# Altium schematic sheet 12008 - Servo CAN Node.SchDoc("12008 - Servo CAN Node")
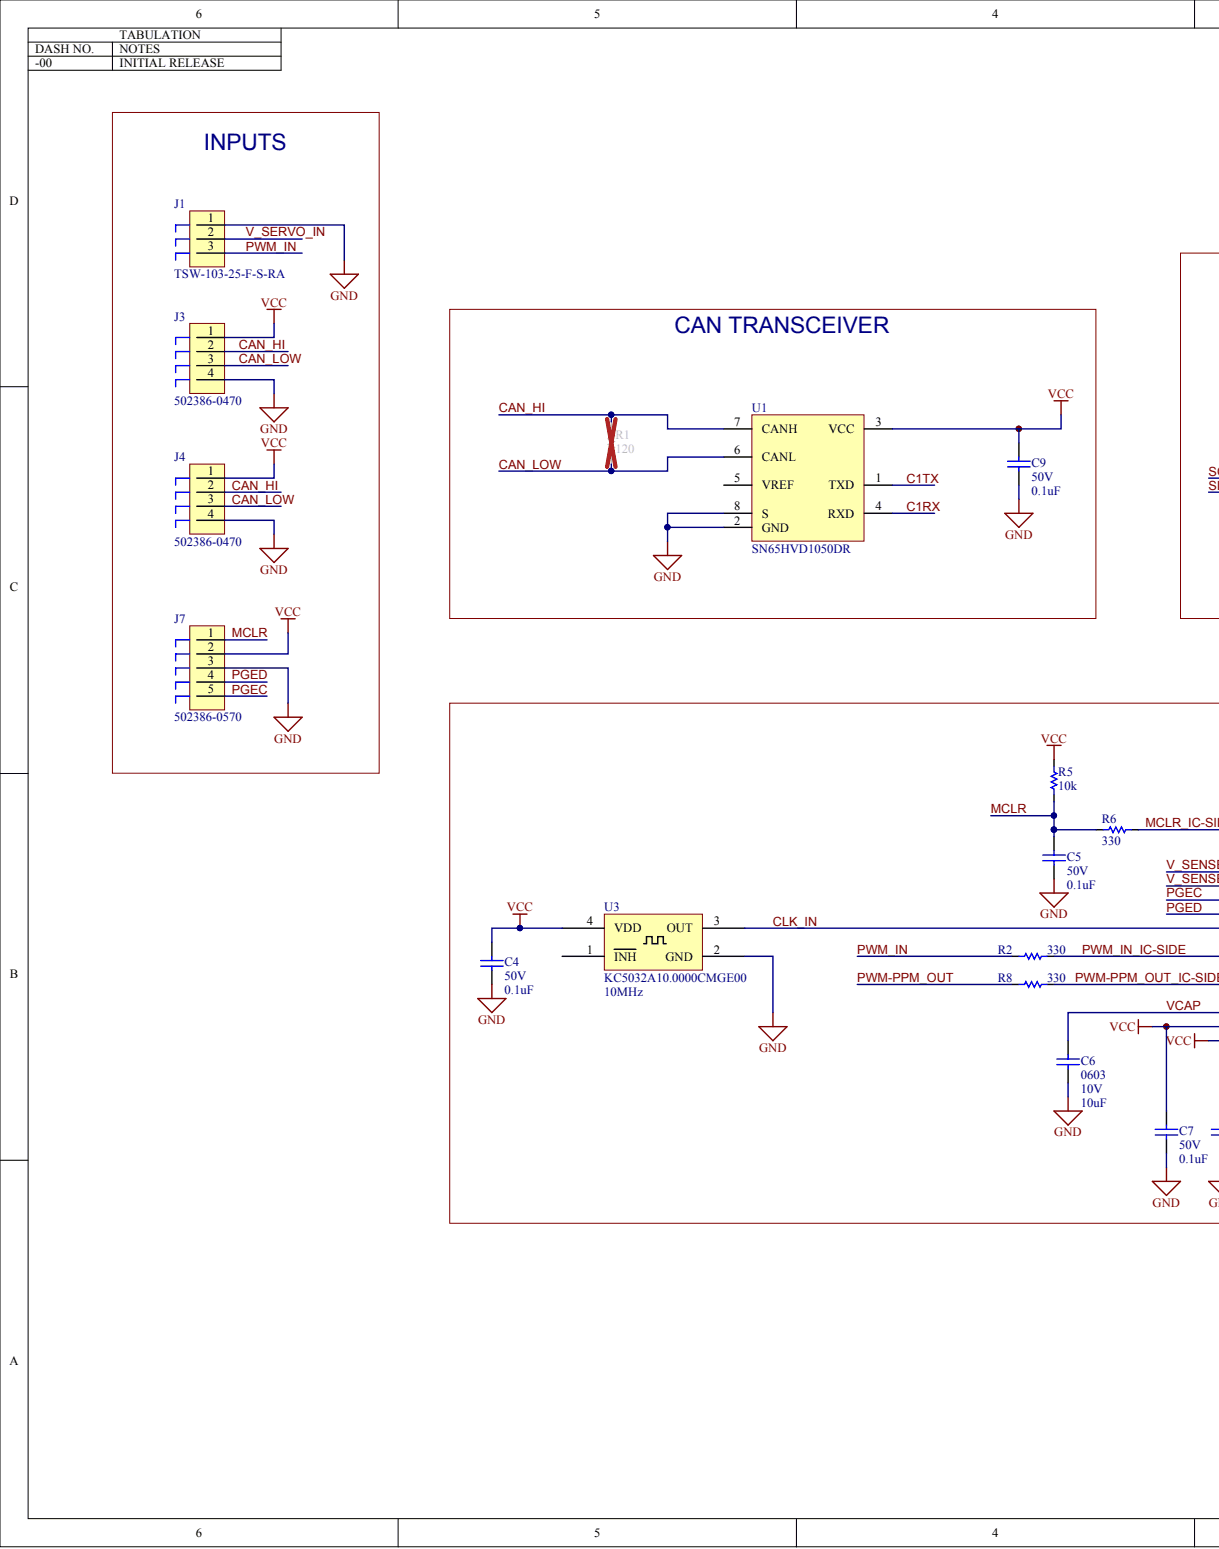
[diagram p0: 12008 - Servo CAN Node.SchDoc, left]
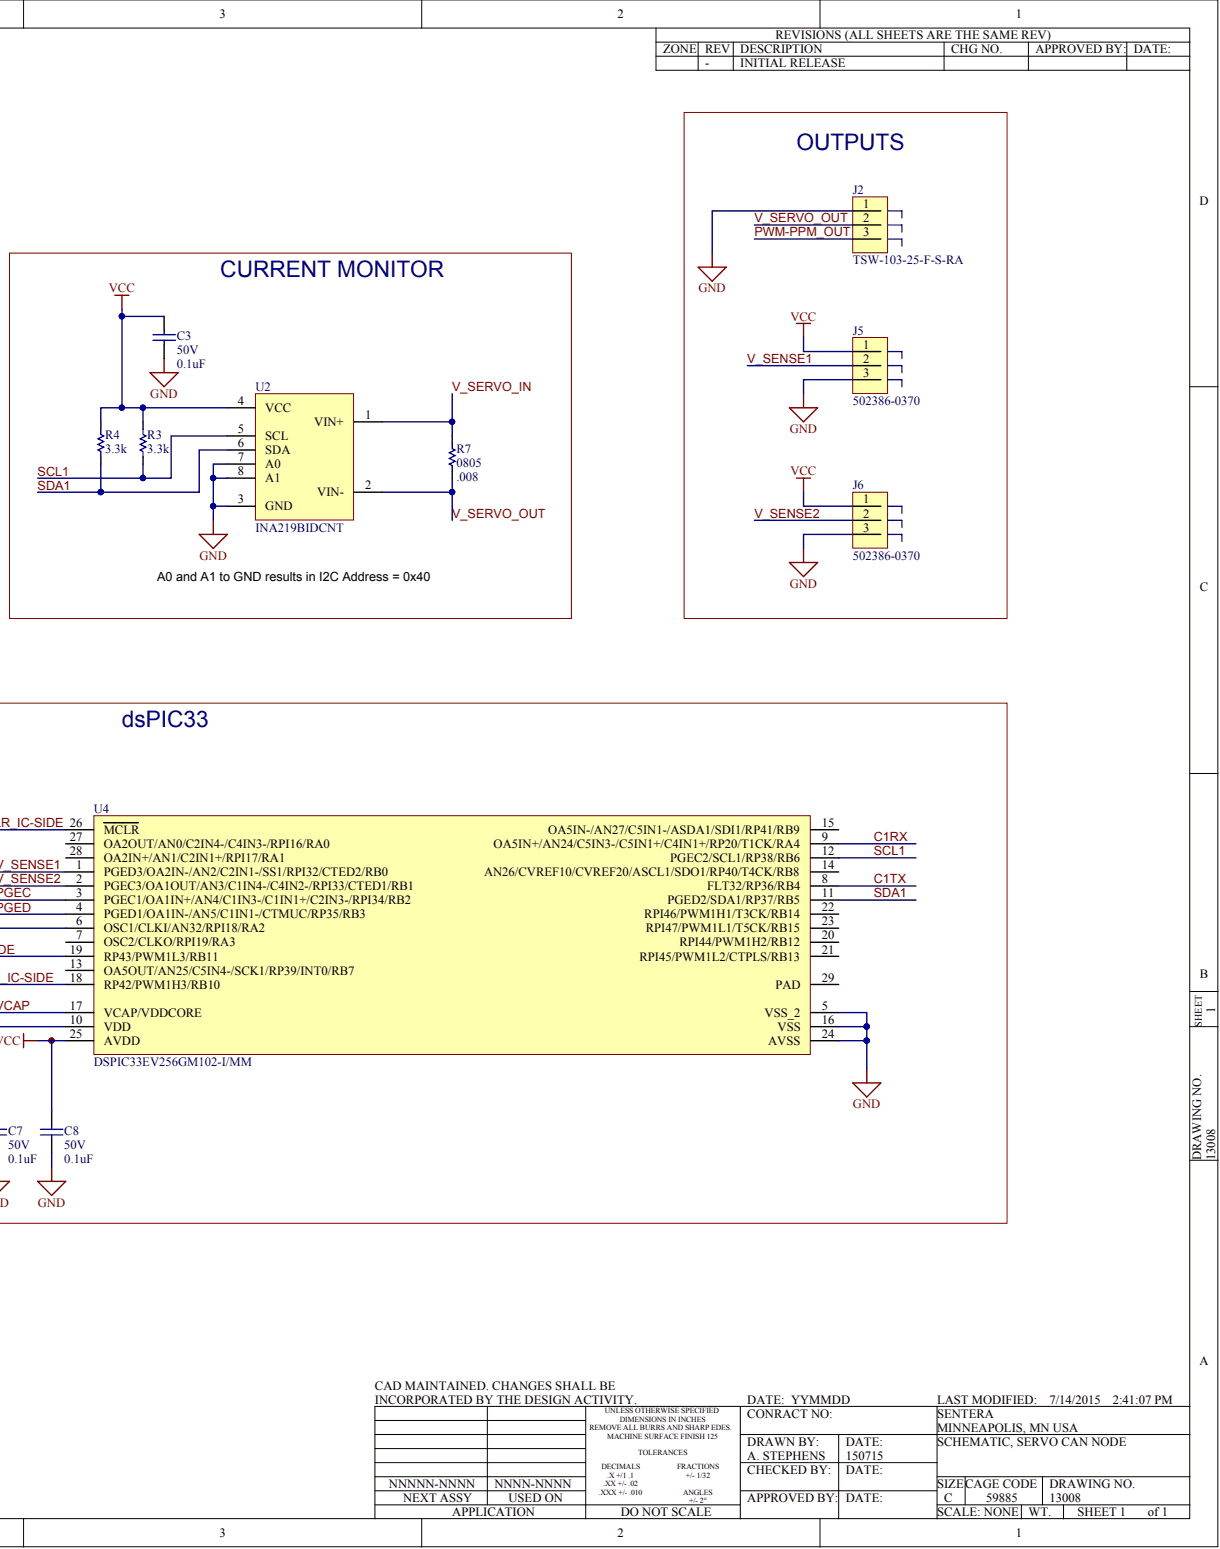
[diagram p1: 12008 - Servo CAN Node.SchDoc, right]

## Parts
C3  value 0.1uF  Voltage - Rated: 50V  [0402 CAPC1005N - MD]  (hidden comment: C1005X7R1H104K)
C4  value 0.1uF  Voltage - Rated: 50V  [0402 CAPC1005N - MD]  (hidden comment: C1005X7R1H104K)
C5  value 0.1uF  Voltage - Rated: 50V  [0402 CAPC1005N - MD]  (hidden comment: C1005X7R1H104K)
C6  value 10uF  Package: 0603  Voltage: 10V  [0603 CAPC1608N - MD]  (hidden comment: C1608X5R1A106M)
C7  value 0.1uF  Voltage - Rated: 50V  [0402 CAPC1005N - MD]  (hidden comment: C1005X7R1H104K)
C8  value 0.1uF  Voltage - Rated: 50V  [0402 CAPC1005N - MD]  (hidden comment: C1005X7R1H104K)
C9  value 0.1uF  Voltage - Rated: 50V  [0402 CAPC1005N - MD]  (hidden comment: C1005X7R1H104K)
J1  TSW-103-25-F-S-RA  [TSW-103-25-F-S-RA]
J2  TSW-103-25-F-S-RA  [TSW-103-25-F-S-RA]
J3  502386-0470  [502386-0470]
J4  502386-0470  [502386-0470]
J5  502386-0370  [502386-0370]
J6  502386-0370  [502386-0370]
J7  502386-0570  [502386-0570]
R1  value 120  [0402 RESC1005N MD]  (hidden comment: ERJ-2RKF1200X)
R2  value 330  [0402 RESC1005N MD]  (hidden comment: ERJ-2RKF3300X)
R3  value 3.3k  [0402 RESC1005N MD]  (hidden comment: ERJ-2RKF3301X)
R4  value 3.3k  [0402 RESC1005N MD]  (hidden comment: ERJ-2RKF3301X)
R5  value 10k  [0402 RESC1005N MD]  (hidden comment: ERJ-2RKF1002X)
R6  value 330  [0402 RESC1005N MD]  (hidden comment: ERJ-2RKF3300X)
R7  value .008  Package / Case: 0805  [0805 RESC2012N - MD]  (hidden comment: PMR10EZPFU8L00)
R8  value 330  [0402 RESC1005N MD]  (hidden comment: ERJ-2RKF3300X)
U1  SN65HVD1050DR  [SOIC127P600X175-8N]
U2  INA219BIDCNT  [SOT23-8 3x3mm]
U3  KC5032A10.0000CMGE00  Frequency: 10MHz  [DFN-4 5x3.2mm]
U4  DSPIC33EV256GM102-I/MM  [QFN-28 6x6mm]

## Nets
GND: C3.2 C4.2 C5.2 C6.2 C7.2 C8.2 C9.2 J1.1 J2.1 J3.4 J4.4 J5.3 J6.3 J7.3 U1.2(GND) U1.8(S) U2.3(GND) U2.7(A0) U2.8(A1) U3.2(GND) U4.5(VSS_2) U4.16(VSS) U4.24(AVSS)
VCC: C3.1 C4.1 C7.1 C8.1 C9.1 J3.1 J4.1 J5.1 J6.1 J7.2 R3.1 R4.1 R5.1 U1.3(VCC) U2.4(VCC) U3.4(VDD) U4.10(VDD) U4.25(AVDD)
C1RX: U1.4(RXD) U4.9(OA5IN+/AN24/C5IN3-/C5IN1+/C4IN1+/RP20/T1CK/RA4)
C1TX: U1.1(TXD) U4.8(FLT32/RP36/RB4)
CAN_HI: J3.2 J4.2 R1.1 U1.7(CANH)
CAN_LOW: J3.3 J4.3 R1.2 U1.6(CANL)
CLK_IN: U3.3(OUT) U4.6(OSC1/CLKI/AN32/RPI18/RA2)
MCLR: C5.1 J7.1 R5.2 R6.1
MCLR_IC-SIDE: R6.2 U4.26(MCLR)
PGEC: J7.5 U4.3(PGEC1/OA1IN+/AN4/C1IN3-/C1IN1+/C2IN3-/RPI34/RB2)
PGED: J7.4 U4.4(PGED1/OA1IN-/AN5/C1IN1-/CTMUC/RP35/RB3)
PWM-PPM_OUT: J2.3 R8.1
PWM-PPM_OUT_IC-SIDE: R8.2 U4.18(RP42/PWM1H3/RB10)
PWM_IN: J1.3 R2.1
PWM_IN_IC-SIDE: R2.2 U4.19(RP43/PWM1L3/RB11)
SCL1: R3.2 U2.5(SCL) U4.12(PGEC2/SCL1/RP38/RB6)
SDA1: R4.2 U2.6(SDA) U4.11(PGED2/SDA1/RP37/RB5)
V_SENSE1: J5.2 U4.1(PGED3/OA2IN-/AN2/C2IN1-/SS1/RPI32/CTED2/RB0)
V_SENSE2: J6.2 U4.2(PGEC3/OA1OUT/AN3/C1IN4-/C4IN2-/RPI33/CTED1/RB1)
V_SERVO_IN: J1.2 R7.1 U2.1(VIN+)
V_SERVO_OUT: J2.2 R7.2 U2.2(VIN-)
VCAP: C6.1 U4.17(VCAP/VDDCORE)

Not connected: U1 5(VREF); U3 1(INH); U4 7(OSC2/CLKO/RPI19/RA3) 13(OA5OUT/AN25/C5IN4-/SCK1/RP39/INT0/RB7) 14(AN26/CVREF10/CVREF20/ASCL1/SDO1/RP40/T4CK/RB8) 15(OA5IN-/AN27/C5IN1-/ASDA1/SDI1/RP41/RB9) 20(RPI44/PWM1H2/RB12) 21(RPI45/PWM1L2/CTPLS/RB13) 22(RPI46/PWM1H1/T3CK/RB14) 23(RPI47/PWM1L1/T5CK/RB15) 27(OA2OUT/AN0/C2IN4-/C4IN3-/RPI16/RA0) 28(OA2IN+/AN1/C2IN1+/RPI17/RA1) 29(PAD)

## Library data of the parts
C3-C5 C7-C9 = C1005X7R1H104K: CAP CER 0.1UF 50V 10% X7R 0402; Manufacturer: TDK Corporation; Approved: 00-111227; Capacitance: 0.1µF; Applications: General Purpose; Category: Capacitors; Component Kind: Standard; ComponentLink1Description: http://www.tdk.co.jp/tefe02/e412_c.pdf; ComponentLink1URL: http://www.tdk.co.jp/tefe02/e412_c.pdf; Features: -; Height - Seated (Max): -; Lead Spacing: -; Lead Style: -; Manufacturer Part Number: C1005X7R1H104K; Modified: 00-111116; Mounting Type: Surface Mount, MLCC; Operating Temperature: -55°C ~ 125°C; Package / Case: 0402 (1005 Metric); Packaging: Cut Tape (CT); Pricing 1: 1=0.3, 10=0.2, 100=0.08, 250=0.05752, 500=0.041, 1000=0.03 (USD); Ratings: -; RoHS: RoHS Compliant; Signal Integrity: Cap; Simulation: CAP; Size / Dimension: 0.039" L x 0.020" W (1.00mm x 0.50mm); Stock 1: 27550; Supplier 1: Digi-Key; Supplier Part Number 1: 445-5932-1-ND; Temperature Coefficient: X7R; Thickness (Max): 0.022" (0.55mm); Tolerance: ±10%; Approved By: D. Schoppe; Library Name: SUAS - Capacitors - Ceramic.SchLib; Modified By: D. Schoppe
C6 = C1608X5R1A106M: CAP CER 10UF 10V X5R 20% 0603; Manufacturer: TDK; Approved: 00-111202; Capacitance: 10µF; Type: Ceramic; Applications: General Purpose; Category: Capacitors; Component Kind: Standard; ComponentLink1Description: http://www.tdk.co.jp/tefe02/e412_c.pdf; ComponentLink1URL: http://www.tdk.co.jp/tefe02/e412_c.pdf; Features: -; Height: -; Lead Spacing: -; Lead Style: -; Manufacturer Part Number: C1608X5R1A106M; Modified: 00-111010; Mounting Type: Surface Mount, MLCC; Operating Temperature: -55°C ~ 85°C; Package / Case: 0603 (1608 Metric); Packaging: Cut Tape (CT); Pricing 1: 1=0.58, 10=0.457, 100=0.275, 250=0.20624, 500=0.176, 1000=0.1375 (USD); Ratings: -; RoHS: RoHS Compliant; Signal Integrity: Cap; Simulation: CAP; Size / Dimension: 0.063" L x 0.031" W (1.60mm x 0.80mm); Stock 1: 16926; Supplier 1: Digi-Key; Supplier Part Number 1: 445-6853-1-ND; Temperature Coefficient: X5R; Thickness: 0.035" (0.90mm); Tolerance: 20%; Voltage - Rated: 10V; Approved By: A. Stephens; Library Name: SUAS - Capacitors - Ceramic.SchLib; Modified By: A. Stephens
J1 J2 = TSW-103-25-F-S-RA: CONN HEADER 3POS .100" R/A AU; Manufacturer: Samtec Inc; Connector Type: Header, Unshrouded; Number of Positions: 3; Number of Positions Loaded: All; Pitch: 0.100" (2.54mm); Category: Connectors, Interconnects; Color: Black; Component Kind: Standard; ComponentLink1Description: http://www.samtec.com/ftppub/cpdf/TSW-XXX-XX-XXX-X-XX-XXX-MKT.pdf; ComponentLink1URL: http://www.samtec.com/ftppub/cpdf/TSW-XXX-XX-XXX-X-XX-XXX-MKT.pdf; Contact Finish: Gold; Contact Finish Thickness: 3µin (0.08µm); Contact Mating Length: 0.320" (8.13mm); Contact Type: Male Pin; Fastening Type: -; Features: -; Manufacturer Part Number: TSW-103-25-F-S-RA; Modified: 00-150714; Mounting Type: Through Hole, Right Angle; Number of Rows: 1; Packaging: Tube; Pricing 1: 1=0.46 (USD); RoHS: RoHS Compliant; Row Spacing: -; Stock 1: 0; Supplier 1: Digi-Key; Supplier Part Number 1: TSW-103-25-F-SRA-ND; Termination: Solder; Library Name: SUAS - Connectors.SchLib; Modified By: A. Stephens
J3 J4 = 502386-0470: CLIK-Mate CONN RCPT 4POS 1.25MM R/A SMD; Manufacturer: Molex Inc; Manufacturer Part Number: 5023860470; Connector Type: Receptacle; Number of Positions: 4; Number of Positions Loaded: All; Pitch: 0.049" (1.25mm); Category: Connectors, Interconnects; Color: Natural; Component Kind: Standard; ComponentLink1Description: http://www.molex.com/pdm_docs/sd/5023860470_sd.pdf; ComponentLink1URL: http://www.molex.com/pdm_docs/sd/5023860470_sd.pdf; Contact Finish: Tin; Contact Finish Thickness: 39µin (1.00µm); Contact Type: Center Strip Contact; Fastening Type: Latch Holder; Features: Solder Retention; Modified: 00-150714; Mounting Type: Surface Mount, Right Angle; Number of Rows: 1; Packaging: Cut Tape (CT); Pricing 1: 1=1.22, 10=1.07, 25=1.0068, 50=0.9646, 100=0.9227, 250=0.83888, 500=0.75498 (USD); RoHS: RoHS Compliant; Row Spacing: -; Stock 1: 3060; Supplier 1: Digi-Key; Supplier Part Number 1: WM4948CT-ND; Termination: Solder; Library Name: SUAS - Connectors.SchLib; Modified By: A. Stephens
J5 J6 = 502386-0370: CONN RCPT 3POS 1.25MM R/A SMD; Manufacturer: Molex Inc; Manufacturer Part Number: 5023860370; Connector Type: Receptacle; Number of Positions: 3; Number of Positions Loaded: All; Pitch: 0.049" (1.25mm); Category: Connectors, Interconnects; Color: Natural; Component Kind: Standard; ComponentLink1Description: http://www.molex.com/pdm_docs/sd/5023860370_sd.pdf; ComponentLink1URL: http://www.molex.com/pdm_docs/sd/5023860370_sd.pdf; Contact Finish: Tin; Contact Finish Thickness: 39µin (1.00µm); Contact Type: Center Strip Contact; Fastening Type: Latch Holder; Features: Solder Retention; Modified: 00-150714; Mounting Type: Surface Mount, Right Angle; Number of Rows: 1; Packaging: Cut Tape (CT); Pricing 1: 1=1.11, 10=0.977, 25=0.9196, 50=0.8814, 100=0.8431, 250=0.76648, 500=0.68984 (USD); RoHS: RoHS Compliant; Row Spacing: -; Stock 1: 1588; Supplier 1: Digi-Key; Supplier Part Number 1: WM4947CT-ND; Termination: Solder; Library Name: SUAS - Connectors.SchLib; Modified By: A. Stephens
J7 = 502386-0570: CLIK-Mate CONN RCPT 5POS 1.25MM R/A SMD; Manufacturer: Molex Inc; Manufacturer Part Number: 5023860570; Connector Type: Receptacle; Number of Positions: 5; Number of Positions Loaded: All; Pitch: 0.049" (1.25mm); Category: Connectors, Interconnects; Color: Natural; Component Kind: Standard; ComponentLink1Description: http://www.molex.com/pdm_docs/sd/5023860570_sd.pdf; ComponentLink1URL: http://www.molex.com/pdm_docs/sd/5023860570_sd.pdf; Contact Finish: Tin; Contact Finish Thickness: 39µin (1.00µm); Contact Type: Center Strip Contact; Fastening Type: Latch Holder; Features: Solder Retention; Modified: 00-150714; Mounting Type: Surface Mount, Right Angle; Number of Rows: 1; Packaging: Cut Tape (CT); Pricing 1: 1=1.34, 10=1.178, 25=1.1088, 50=1.0626, 100=1.0164, 250=0.924, 500=0.8316 (USD); RoHS: RoHS Compliant; Row Spacing: -; Stock 1: 9764; Supplier 1: Digi-Key; Supplier Part Number 1: WM4949CT-ND; Termination: Solder; Library Name: SUAS - Connectors.SchLib; Modified By: A. Stephens
R1 = ERJ-2RKF1200X: RES 120 OHM 1/10W 1% 0402 SMD; Manufacturer: Panasonic Electronic Components; Power (Watts): 0.1W, 1/10W; Temperature Coefficient: ±100ppm/°C; Category: Resistors; Component Kind: Standard; ComponentLink1Description: http://industrial.panasonic.com/www-cgi/jvcr13pz.cgi?E+PZ+3+AOA0002+ERJ2RKF1200X+7+WW; ComponentLink1URL: http://industrial.panasonic.com/www-cgi/jvcr13pz.cgi?E+PZ+3+AOA0002+ERJ2RKF1200X+7+WW; Composition: Thick Film; Features: Automotive AEC-Q200; Height: 0.016" (0.40mm); Manufacturer Part Number: ERJ-2RKF1200X; Modified: 00-150714; Number of Terminations: 2; Operating Temperature: -55°C ~ 155°C; Package / Case: 0402 (1005 Metric); Packaging: Cut Tape (CT); Pricing 1: 1=0.1, 50=0.015, 100=0.011, 250=0.0084, 500=0.00674, 1000=0.00496, 5000=0.00355 (USD); Resistance (Ohms): 120; RoHS: RoHS Compliant; Signal Integrity: Res; Simulation: RESISTOR; Size / Dimension: 0.039" L x 0.020" W (1.00mm x 0.50mm); Stock 1: 185722; Supplier 1: Digi-Key; Supplier Device Package: 0402; Supplier Part Number 1: P120LCT-ND; Tolerance: ±1%; Library Name: SUAS - Resistors.SchLib; Modified By: A. Stephens
R2 R6 R8 = ERJ-2RKF3300X: RES 330 OHM 1/10W 1% 0402 SMD; Manufacturer: Panasonic; Approved: 00-111010; Power (Watts): 0.1W, 1/10W; Temperature Coefficient: ±100ppm/°C; Category: Resistors; Component Kind: Standard; ComponentLink1Description: http://industrial.panasonic.com/www-cgi/jvcr13pz.cgi?E+PZ+3+AOA0002+ERJ2RKF3300X+7+WW; ComponentLink1URL: http://industrial.panasonic.com/www-cgi/jvcr13pz.cgi?E+PZ+3+AOA0002+ERJ2RKF3300X+7+WW; Composition: Thick Film; Features: -; Height: 0.016" (0.40mm); Manufacturer Part Number: ERJ-2RKF3300X; Modified: 00-111010; Number of Terminations: 2; Package: 0402; Package / Case: 0402 (1005 Metric); Packaging: Cut Tape (CT); Power: 1/10W; Pricing 1: 1=0.06, 50=0.0242, 100=0.0184, 250=0.01364, 500=0.01008, 1000=0.00672, 5000=0.00473 (USD); Resistance (Ohms): 330; RoHS: RoHS Compliant; Signal Integrity: Res; Simulation: RESISTOR; Size / Dimension: 0.039" L x 0.020" W (1.00mm x 0.50mm); Stock 1: 462252; Supplier 1: Digi-Key; Supplier Part Number 1: P330LCT-ND; Tolerance: 1%; Approved By: A. Stephens; Library Name: SUAS - Resistors.SchLib; Modified By: A. Stephens
R3 R4 = ERJ-2RKF3301X: RES 3.30K OHM 1/10W 1% 0402 SMD; Manufacturer: Panasonic - ECG; Approved: 00-120430; Power (Watts): 0.1W, 1/10W; Temperature Coefficient: ±100ppm/°C; Category: Resistors; Component Kind: Standard; ComponentLink1Description: http://industrial.panasonic.com/www-cgi/jvcr13pz.cgi?E+PZ+3+AOA0002+ERJ2RKF3301X+7+WW; ComponentLink1URL: http://industrial.panasonic.com/www-cgi/jvcr13pz.cgi?E+PZ+3+AOA0002+ERJ2RKF3301X+7+WW; Composition: Thick Film; Features: -; Height: 0.016" (0.40mm); Manufacturer Part Number: ERJ-2RKF3301X; Modified: 00-120423; Number of Terminations: 2; Package / Case: 0402 (1005 Metric); Packaging: Cut Tape (CT); Pricing 1: 1=0.06, 50=0.0242, 100=0.0184, 250=0.01364, 500=0.01008, 1000=0.00672, 5000=0.00473 (USD); Resistance (Ohms): 3.3K; RoHS: RoHS Compliant; Signal Integrity: Res; Simulation: RESISTOR; Size / Dimension: 0.039" L x 0.020" W (1.00mm x 0.50mm); Stock 1: 211110; Supplier 1: Digi-Key; Supplier Part Number 1: P3.30KLCT-ND; Tolerance: ±1%; Approved By: A. Stephens; Library Name: SUAS - Resistors.SchLib; Modified By: A. Stephens
R5 = ERJ-2RKF1002X: RES 10.0K OHM 1/10W 1% 0402 SMD; Manufacturer: Panasonic; Approved: 00-111010; Power (Watts): 0.1W, 1/10W; Temperature Coefficient: ±100ppm/°C; Category: Resistors; Component Kind: Standard; ComponentLink1Description: http://industrial.panasonic.com/www-cgi/jvcr13pz.cgi?E+PZ+3+AOA0002+ERJ2RKF1002X+7+WW; ComponentLink1URL: http://industrial.panasonic.com/www-cgi/jvcr13pz.cgi?E+PZ+3+AOA0002+ERJ2RKF1002X+7+WW; Composition: Thick Film; Features: -; Height: 0.016" (0.40mm); Manufacturer Part Number: ERJ-2RKF1002X; Modified: 00-111010; Number of Terminations: 2; Package: 0402; Package / Case: 0402 (1005 Metric); Packaging: Cut Tape (CT); Power: 1/10W; Pricing 1: 1=0.06, 50=0.0242, 100=0.0184, 250=0.01364, 500=0.01008, 1000=0.00672, 5000=0.00473 (USD); Resistance (Ohms): 10K; RoHS: RoHS Compliant; Signal Integrity: Res; Simulation: RESISTOR; Size / Dimension: 0.039" L x 0.020" W (1.00mm x 0.50mm); Stock 1: 15215477; Supplier 1: Digi-Key; Supplier Part Number 1: P10.0KLCT-ND; Tolerance: 1%; Approved By: A. Stephens; Library Name: SUAS - Resistors.SchLib; Modified By: A. Stephens
R7 = PMR10EZPFU8L00: RES SMD 0.008 OHM 1% 1/2W 0805; Manufacturer: Rohm Semiconductor; Power (Watts): 0.5W, 1/2W; Resistance (Ohms): 0.008; Temperature Coefficient: ±150ppm/°C; Category: Resistors; Component Kind: Standard; ComponentLink1Description: http://rohmfs.rohm.com/en/products/databook/datasheet/passive/resistor/chip_resistor/pmr.pdf; ComponentLink1URL: http://rohmfs.rohm.com/en/products/databook/datasheet/passive/resistor/chip_resistor/pmr.pdf; Composition: Thick Film; Features: Automotive AEC-Q200, Current Sense; Height: 0.019" (0.47mm); Manufacturer Part Number: PMR10EZPFU8L00; Modified: 00-150714; Number of Terminations: 2; Operating Temperature: -55°C ~ 155°C; Packaging: Cut Tape (CT); Pricing 1: 1=0.62, 10=0.52, 25=0.4456, 100=0.349, 250=0.31184, 500=0.25246, 1000=0.20419 (USD); RoHS: RoHS Compliant; Size / Dimension: 0.079" L x 0.047" W (2.00mm x 1.20mm); Stock 1: 8069; Supplier 1: Digi-Key; Supplier Device Package: 0805 (2012 Metric); Supplier Part Number 1: RHM.008AJCT-ND; Tolerance: ±1%; Library Name: SUAS - Resistors.SchLib; Modified By: A. Stephens
U1 = SN65HVD1050DR: IC EMC CAN TRANSCEIVER 8-SOIC; Manufacturer: Texas Instruments; Duplex: Half; Number of Drivers/Receivers: 1/1; Receiver Hysteresis: 125mV; Voltage - Supply: 4.5 V ~ 5.5 V; Category: Integrated Circuits (ICs); Component Kind: Standard; ComponentLink1Description: http://www.ti.com/lit/ds/symlink/sn65hvd1050.pdf; ComponentLink1URL: http://www.ti.com/lit/ds/symlink/sn65hvd1050.pdf; Data Rate: -; Manufacturer Part Number: SN65HVD1050DR; Modified: 00-150714; Mounting Type: Surface Mount; Operating Temperature: -40°C ~ 125°C; Package / Case: 8-SOIC (0.154", 3.90mm Width); Packaging: Cut Tape (CT); Pricing 1: 1=1.29, 10=1.14, 25=1.03, 50=0.96, 100=0.907, 250=0.774, 500=0.684, 750=0.598, 1000=0.45 (USD); Protocol: CAN; RoHS: RoHS Compliant; Stock 1: 11992; Supplier 1: Digi-Key; Supplier Device Package: 8-SOIC; Supplier Part Number 1: 296-26335-1-ND; Type: Transceiver; Library Name: SUAS - Interface Transceivers.SchLib; Modified By: A. Stephens
U2 = INA219BIDCNT: IC MONITOR PWR/CURR BID SOT-23-8; Manufacturer: Texas Instruments; Accuracy: ±0.5%; Current - Output: 10mA; Function: Current Monitor; Sensing Method: High-Side; Voltage - Input: 0 V ~ 26 V; Category: Integrated Circuits (ICs); Component Kind: Standard; ComponentLink1Description: http://www.ti.com/lit/ds/symlink/ina219.pdf; ComponentLink1URL: http://www.ti.com/lit/ds/symlink/ina219.pdf; Manufacturer Part Number: INA219BIDCNT; Modified: 00-150714; Mounting Type: Surface Mount; Operating Temperature: -40°C ~ 125°C; Package / Case: SOT-23-8; Packaging: Cut Tape (CT); Pricing 1: 1=3.02, 10=2.72, 25=2.36, 50=2.22, 100=2.19 (USD); RoHS: RoHS Compliant; Stock 1: 2387; Supplier 1: Digi-Key; Supplier Device Package: SOT-23-8; Supplier Part Number 1: 296-27898-1-ND; Library Name: SUAS - PMIC - Other.SchLib; Modified By: A. Stephens
U3 = KC5032A10.0000CMGE00: OSCILLATOR XO 10.000MHZ CMOS SMD; Manufacturer: AVX Corp/Kyocera Corp; Current - Supply (Disable) (Max): 10µA; Current - Supply (Max): 7mA; Frequency Stability: ±50ppm; Function: Tri-State (Output Enable); Voltage - Supply: 1.6 V ~ 5.5 V; Category: Crystals and Oscillators; Component Kind: Standard; ComponentLink1Description: http://www.kyocera-crystal.jp/eng/wp-content/uploads/2014/09/kc5032a_cm_e.pdf; ComponentLink1URL: http://www.kyocera-crystal.jp/eng/wp-content/uploads/2014/09/kc5032a_cm_e.pdf; Height: 0.039" (1.00mm); Manufacturer Part Number: KC5032A10.0000CMGE00; Modified: 00-150714; Mounting Type: Surface Mount; Operating Temperature: -40°C ~ 85°C; Output: CMOS; Package / Case: 4-SMD, No Lead (DFN, LCC); Packaging: Cut Tape (CT); Pricing 1: 1=1.56, 10=1.492, 50=1.4586, 100=1.2474, 500=1.2144 (USD); Ratings: -; RoHS: RoHS Compliant; Size / Dimension: 0.197" L x 0.126" W (5.00mm x 3.20mm); Stock 1: 1323; Supplier 1: Digi-Key; Supplier Part Number 1: 1253-1284-1-ND; Type: XO (Standard); Library Name: SUAS - Crystals, Oscillators.SchLib; Modified By: A. Stephens
U4 = DSPIC33EV256GM102-I/MM: IC DSC 16BIT 16KB; Manufacturer: Microchip Technology; Connectivity: CAN, I²C, IrDA, LIN, SPI, UART/USART; Core Processor: dsPIC; Core Size: 16-Bit; Data Converters: A/D 11x10/12b; Number of I/O: 21; Oscillator Type: Internal; Peripherals: Brown-out Detect/Reset, DMA, POR, PWM, WDT; Program Memory Size: 256KB (85.5K x 24); Program Memory Type: FLASH; RAM Size: 16K x 8; Speed: 70 MIPs; Voltage - Supply (Vcc/Vdd): 4.5 V ~ 5.5 V; Category: Integrated Circuits (ICs); Component Kind: Standard; ComponentLink1Description: http://www.microchip.com/mymicrochip/filehandler.aspx?ddocname=en572363; ComponentLink1URL: http://www.microchip.com/mymicrochip/filehandler.aspx?ddocname=en572363; EEPROM Size: -; Manufacturer Part Number: DSPIC33EV256GM102-I/MM; Modified: 00-150714; Operating Temperature: -40°C ~ 85°C; Package / Case: 28-VQFN Exposed Pad; Packaging: Tube; Pricing 1: 1=5.81, 10=4.84, 25=4.43, 100=4.02 (USD); RoHS: RoHS Compliant; Stock 1: 184; Supplier 1: Digi-Key; Supplier Device Package: 28-QFN-S (6x6); Supplier Part Number 1: DSPIC33EV256GM102-I/MM-ND; Library Name: SUAS - Microcontrollers.SchLib; Modified By: A. Stephens
